# Altium schematic sheet Cheap_FOCer.SchDoc
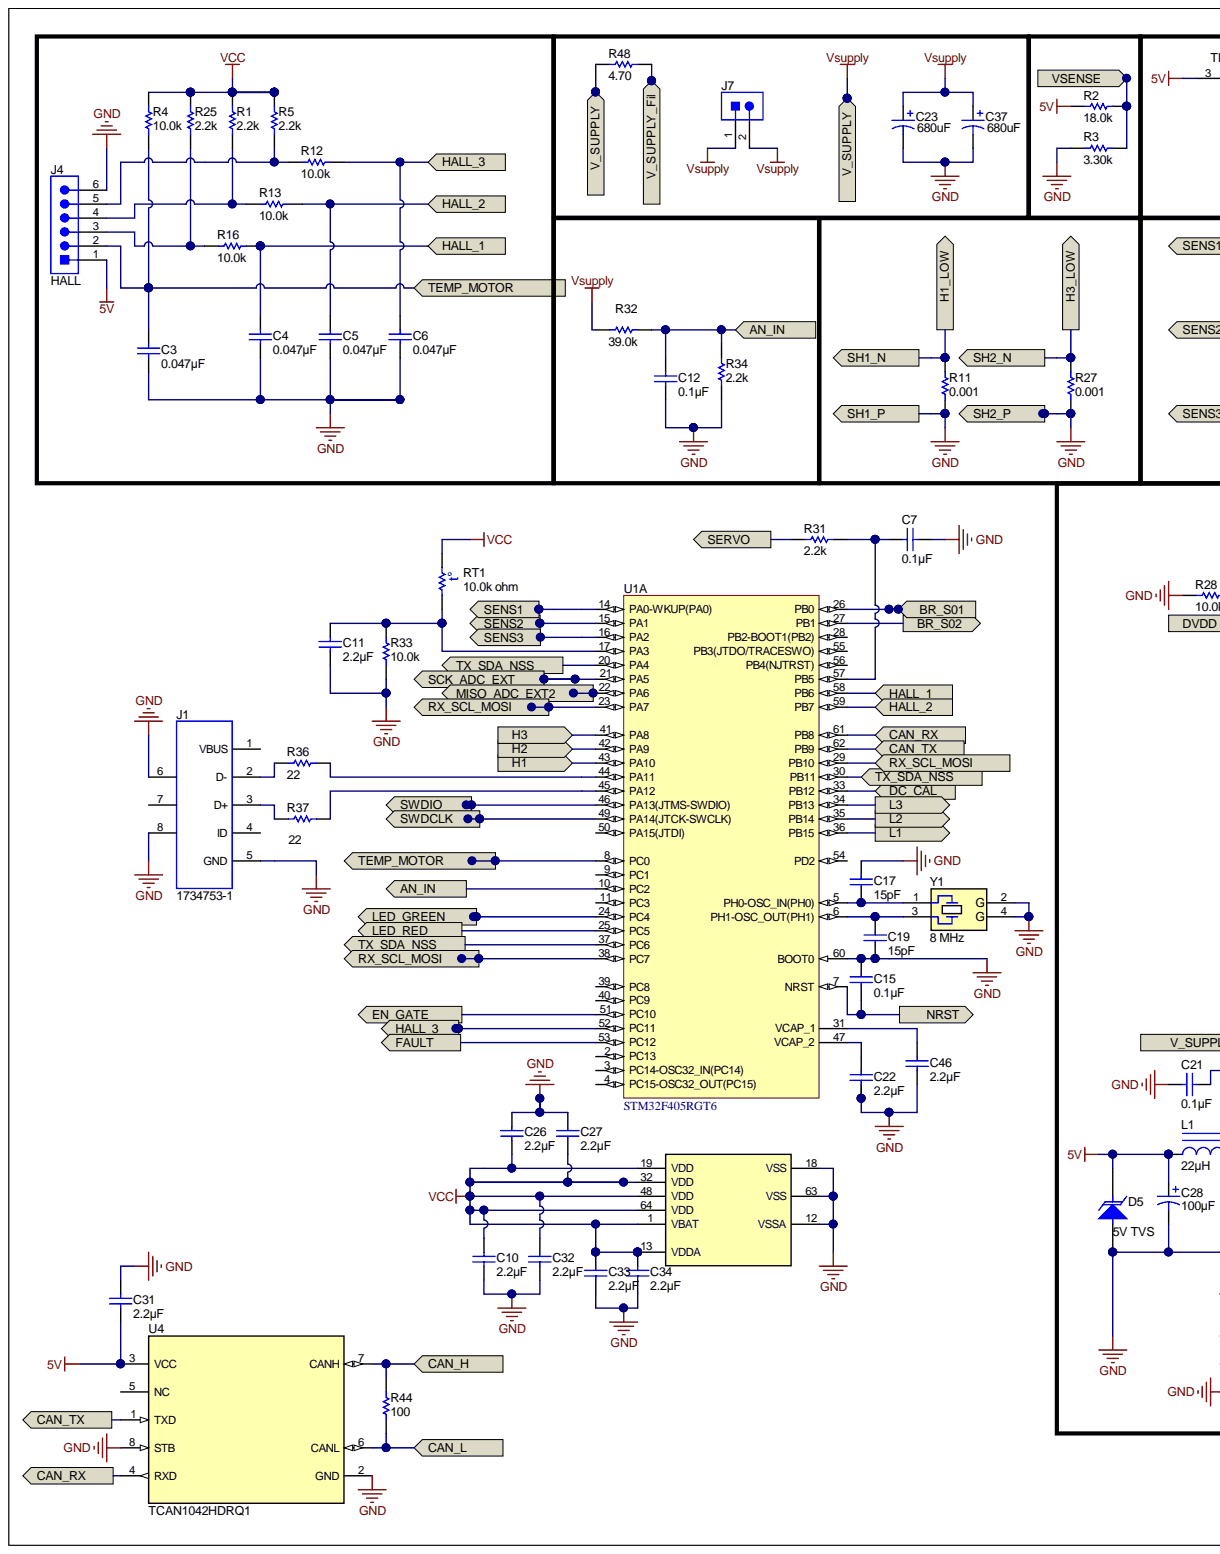
[diagram p0: Cheap_FOCer.SchDoc, left]
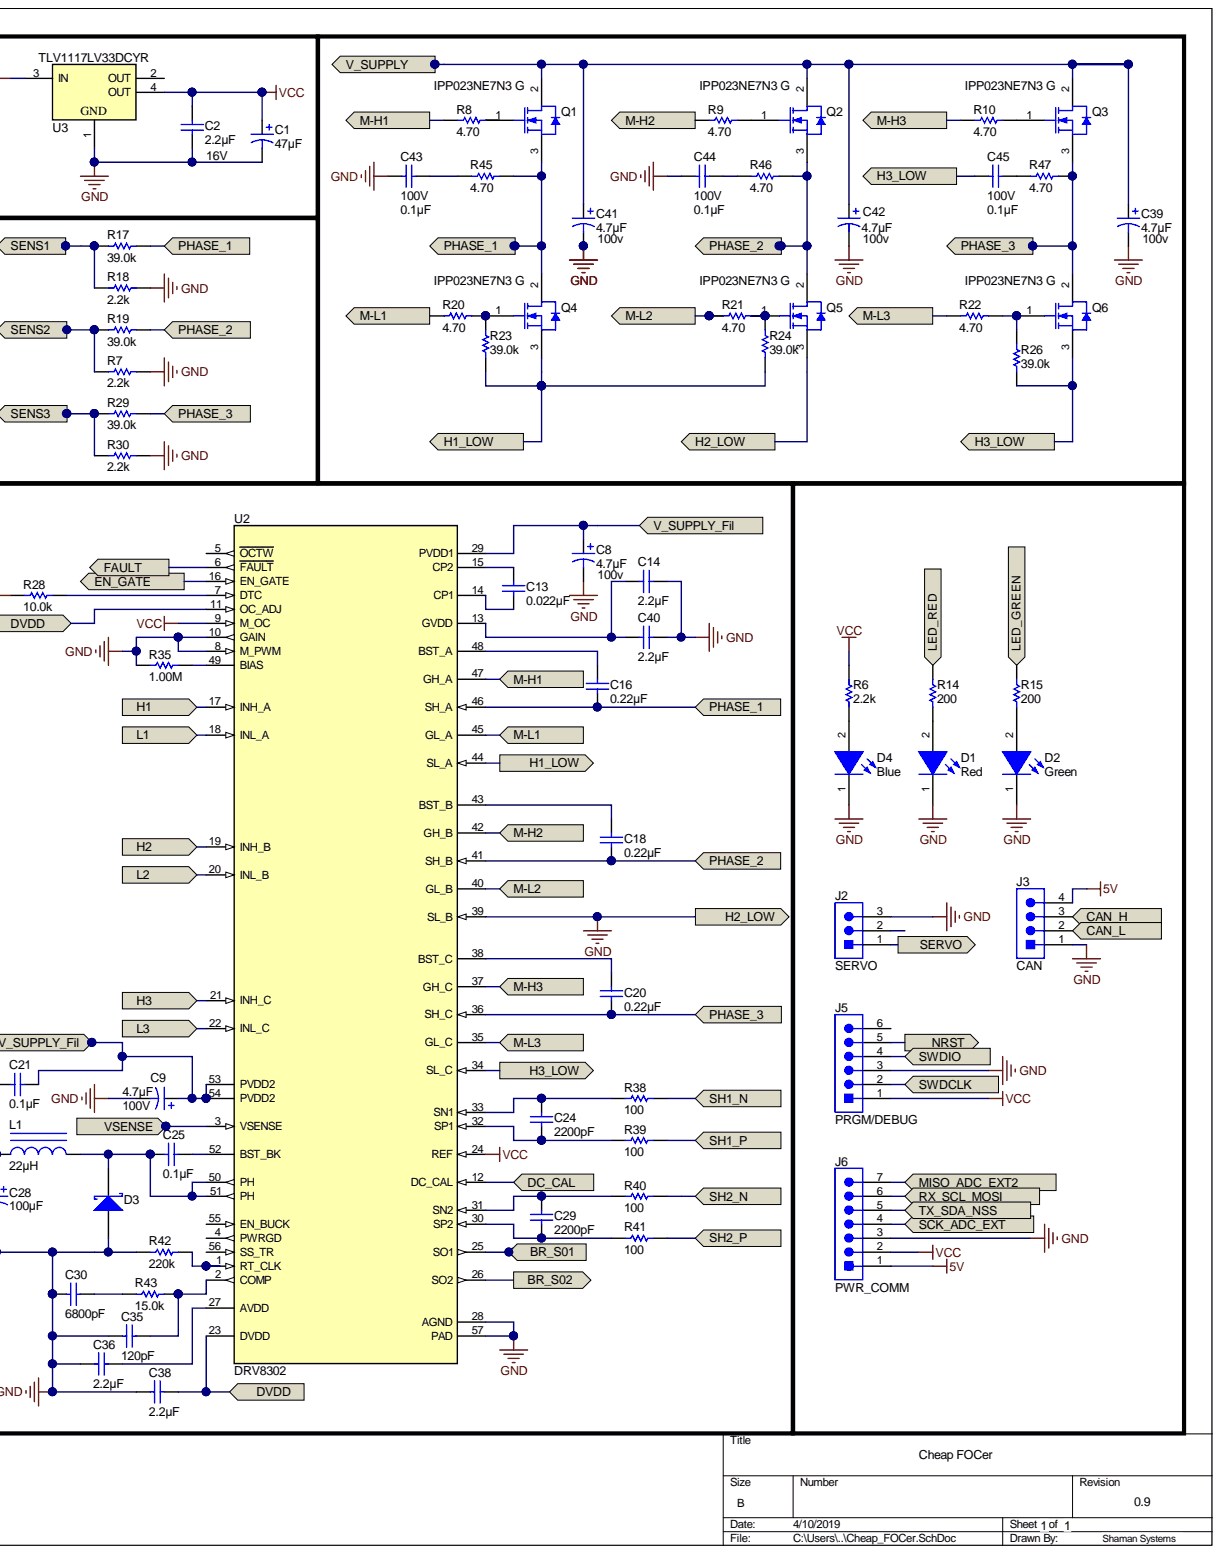
[diagram p1: Cheap_FOCer.SchDoc, right]

## Parts
C1  ValueDisplayed: 47µF  [FC_500x1100]  (hidden comment: EEU-FC1C470)
C2  Value2: 16V  ValueDisplayed: 2.2µF  [0805_HV]  (hidden comment: EMK212B7225KG-T)
C3  ValueDisplayed: 0.047µF  [0805_HV]  (hidden comment: GRM219R71E473KA01D)
C4  ValueDisplayed: 0.047µF  [0805_HV]  (hidden comment: GRM219R71E473KA01D)
C5  ValueDisplayed: 0.047µF  [0805_HV]  (hidden comment: GRM219R71E473KA01D)
C6  ValueDisplayed: 0.047µF  [0805_HV]  (hidden comment: GRM219R71E473KA01D)
C7  ValueDisplayed: 0.1µF  [0805_HV]  (hidden comment: C0805C104K4RACTU)
C8  Value2: 100v  ValueDisplayed: 4.7µF  [Chemi-Con_500x1100]  (hidden comment: EKMG500ELL4R7ME11D)
C9  Value2: 100V  ValueDisplayed: 4.7µF  [Chemi-Con_500x1100]  (hidden comment: EKMG500ELL4R7ME11D)
C10  ValueDisplayed: 2.2µF  [0805_HV]  (hidden comment: EMK212B7225KG-T)
C11  ValueDisplayed: 2.2µF  [0805_HV]  (hidden comment: EMK212B7225KG-T)
C12  ValueDisplayed: 0.1µF  [0805_HV]  (hidden comment: C0805C104K4RACTU)
C13  ValueDisplayed: 0.022µF  [0805_HV]  (hidden comment: 08055C223KAT2A)
C14  ValueDisplayed: 2.2µF  [0805_HV]  (hidden comment: EMK212B7225KG-T)
C15  ValueDisplayed: 0.1µF  [0805_HV]  (hidden comment: C0805C104K4RACTU)
C16  ValueDisplayed: 0.22µF  [0805_HV]  (hidden comment: GRM21BR71H224KA01L)
C17  value 15pF  [0805_HV]  (hidden comment: C0805C150J5GACTU)
C18  ValueDisplayed: 0.22µF  [0805_HV]  (hidden comment: GRM21BR71H224KA01L)
C19  value 15pF  [0805_HV]  (hidden comment: C0805C150J5GACTU)
C20  ValueDisplayed: 0.22µF  [0805_HV]  (hidden comment: GRM21BR71H224KA01L)
C21  ValueDisplayed: 0.1µF  [0805_HV]  (hidden comment: C0805C104K4RACTU)
C22  ValueDisplayed: 2.2µF  [0805_HV]  (hidden comment: EMK212B7225KG-T)
C23  ValueDisplayed: 680uF  [B41866_1600x2000]  (hidden comment: B41866C6567M)
C24  value 2200pF  [0805_HV]  (hidden comment: 08055A222JAT2A)
C25  ValueDisplayed: 0.1µF  [0805_HV]  (hidden comment: C0805C104K4RACTU)
C26  ValueDisplayed: 2.2µF  [0805_HV]  (hidden comment: EMK212B7225KG-T)
C27  ValueDisplayed: 2.2µF  [0805_HV]  (hidden comment: EMK212B7225KG-T)
C28  ValueDisplayed: 100µF  [FC_500x1100]  (hidden comment: EEU-FC1C470)
C29  value 2200pF  [0805_HV]  (hidden comment: 08055A222JAT2A)
C30  value 6800pF  [0805_HV]  (hidden comment: GRM2195C1H682FA01D)
C31  ValueDisplayed: 2.2µF  [0805_HV]  (hidden comment: EMK212B7225KG-T)
C32  ValueDisplayed: 2.2µF  [0805_HV]  (hidden comment: EMK212B7225KG-T)
C33  ValueDisplayed: 2.2µF  [0805_HV]  (hidden comment: EMK212B7225KG-T)
C34  ValueDisplayed: 2.2µF  [0805_HV]  (hidden comment: EMK212B7225KG-T)
C35  value 120pF  [0805_HV]  (hidden comment: 08055A121JAT2A)
C36  ValueDisplayed: 2.2µF  [0805_HV]  (hidden comment: EMK212B7225KG-T)
C37  ValueDisplayed: 680uF  [B41866_1600x2000]  (hidden comment: B41866C6567M)
C38  ValueDisplayed: 2.2µF  [0805_HV]  (hidden comment: EMK212B7225KG-T)
C39  Value2: 100v  ValueDisplayed: 4.7µF  [Chemi-Con_500x1100]  (hidden comment: EKMG500ELL4R7ME11D)
C40  ValueDisplayed: 2.2µF  [0805_HV]  (hidden comment: EMK212B7225KG-T)
C41  Value2: 100v  ValueDisplayed: 4.7µF  [Chemi-Con_500x1100]  (hidden comment: EKMG500ELL4R7ME11D)
C42  Value2: 100v  ValueDisplayed: 4.7µF  [Chemi-Con_500x1100]  (hidden comment: EKMG500ELL4R7ME11D)
C43  Value2: 100V  ValueDisplayed: 0.1µF  [FKP2_720x450x600]  (hidden comment: FKP2D001001D00HSSD)
C44  Value2: 100V  ValueDisplayed: 0.1µF  [FKP2_720x450x600]  (hidden comment: FKP2D001001D00HSSD)
C45  Value2: 100V  ValueDisplayed: 0.1µF  [FKP2_720x450x600]  (hidden comment: FKP2D001001D00HSSD)
C46  ValueDisplayed: 2.2µF  [0805_HV]  (hidden comment: EMK212B7225KG-T)
D1  value Red  Color: Red  [WL-SMCW_RED_0805]  (hidden comment: 150080SS75000)
D2  value Green  Color: Green  [WL-SMCW_Green_0805]  (hidden comment: 150080GS75000)
D3  (no value)  [SMB]
D4  value Blue  [WL-SMCW_RED_0805]  (hidden comment: 150080SS75000)
D5  5V TVS  value 5V  CompType: TVS  [SMA]
J1  1734753-1  [TE_1734753-1]
J2  SERVO  [Mill-Max_800-10-003-10-001000]
J3  CAN  [Mill-Max_800-10-004-10-001000]
J4  HALL  [Mill-Max_800-10-006-10-001000]
J5  PRGM/DEBUG  [Mill-Max_800-10-006-10-001000]
J6  PWR_COMM  [Mill-Max_800-10-007-10-001000]
J7  0022284023  [Molex_0022284023]  (value not drawn)
L1  ValueDisplayed: 22µH  [SRP6540]  (hidden comment: SRP6540-220M)
Q1  IPP023NE7N3 G  [TO-220AB]
Q2  IPP023NE7N3 G  [TO-220AB]
Q3  IPP023NE7N3 G  [TO-220AB]
Q4  IPP023NE7N3 G  [TO-220AB]
Q5  IPP023NE7N3 G  [TO-220AB]
Q6  IPP023NE7N3 G  [TO-220AB]
R1  value 2.2k  [0805_HV]  (hidden comment: CRCW08052K20JNEA)
R2  value 18.0k  [0805_HV]  (hidden comment: RC0805FR-0718KL)
R3  value 3.30k  [0805_HV]  (hidden comment: RG2012P-332-B-T5)
R4  value 10.0k  [0805_HV]  (hidden comment: CRCW080510K0FKEA)
R5  value 2.2k  [0805_HV]  (hidden comment: CRCW08052K20JNEA)
R6  value 2.2k  [0805_HV]  (hidden comment: CRCW08052K20JNEA)
R7  value 2.2k  [0805_HV]  (hidden comment: CRCW08052K20JNEA)
R8  value 4.70  [1206]  (hidden comment: RC1206FR-074R7L)
R9  value 4.70  [1206]  (hidden comment: RC1206FR-074R7L)
R10  value 4.70  [1206]  (hidden comment: RC1206FR-074R7L)
R11  value 0.001  Res_ohm: 0.001  [2512]  (hidden comment: ERJ-M1WTF1M0U)
R12  value 10.0k  [0805_HV]  (hidden comment: CRCW080510K0FKEA)
R13  value 10.0k  [0805_HV]  (hidden comment: CRCW080510K0FKEA)
R14  value 200  [0805_HV]  (hidden comment: CRCW0805200RFKEA)
R15  value 200  [0805_HV]  (hidden comment: CRCW0805200RFKEA)
R16  value 10.0k  [0805_HV]  (hidden comment: CRCW080510K0FKEA)
R17  value 39.0k  [0805_HV]  (hidden comment: RG2012P-393-B-T5)
R18  value 2.2k  [0805_HV]  (hidden comment: CRCW08052K20JNEA)
R19  value 39.0k  [0805_HV]  (hidden comment: RG2012P-393-B-T5)
R20  value 4.70  [1206]  (hidden comment: RC1206FR-074R7L)
R21  value 4.70  [1206]  (hidden comment: RC1206FR-074R7L)
R22  value 4.70  [1206]  (hidden comment: RC1206FR-074R7L)
R23  value 39.0k  [0805_HV]  (hidden comment: RG2012P-393-B-T5)
R24  value 39.0k  [0805_HV]  (hidden comment: RG2012P-393-B-T5)
R25  value 2.2k  [0805_HV]  (hidden comment: CRCW08052K20JNEA)
R26  value 39.0k  [0805_HV]  (hidden comment: RG2012P-393-B-T5)
R27  value 0.001  Res_ohm: 0.001  [2512]  (hidden comment: ERJ-M1WTF1M0U)
R28  value 10.0k  [0805_HV]  (hidden comment: CRCW080510K0FKEA)
R29  value 39.0k  [0805_HV]  (hidden comment: RG2012P-393-B-T5)
R30  value 2.2k  [0805_HV]  (hidden comment: CRCW08052K20JNEA)
R31  value 2.2k  [0805_HV]  (hidden comment: CRCW08052K20JNEA)
R32  value 39.0k  [0805_HV]  (hidden comment: RG2012P-393-B-T5)
R33  value 10.0k  [0805_HV]  (hidden comment: CRCW080510K0FKEA)
R34  value 2.2k  [0805_HV]  (hidden comment: CRCW08052K20JNEA)
R35  ValueDisplayed: 1.00M  [0805_HV]  (hidden comment: ERJ-6ENF1004V)
R36  value 22  [0805_HV]  (hidden comment: CRCW080522R0JNEA)
R37  value 22  [0805_HV]  (hidden comment: CRCW080522R0JNEA)
R38  value 100  [0805_HV]  (hidden comment: CRCW0805100RFKEA)
R39  value 100  [0805_HV]  (hidden comment: CRCW0805100RFKEA)
R40  value 100  [0805_HV]  (hidden comment: CRCW0805100RFKEA)
R41  value 100  [0805_HV]  (hidden comment: CRCW0805100RFKEA)
R42  value 220k  [0805_HV]  (hidden comment: RG2012P-224-B-T5)
R43  value 15.0k  [0805_HV]  (hidden comment: ERJ-6ENF1502V)
R44  value 100  [0805_HV]  (hidden comment: CRCW0805100RFKEA)
R45  value 4.70  [1206]  (hidden comment: RC1206FR-074R7L)
R46  value 4.70  [1206]  (hidden comment: RC1206FR-074R7L)
R47  value 4.70  [1206]  (hidden comment: RC1206FR-074R7L)
R48  value 4.70  [1206]  (hidden comment: RC1206FR-074R7L)
RT1  value 10.0k ohm  [Thermistor_103AT-2]  (hidden comment: 103AT-2)
U1  STM32F405RGT6  [STM-LQFP64_N]  (part A)
U2  PartNumber: DRV8302  [DCA0056A_L]  (hidden comment: DRV8301DCA)
U3  TLV1117LV33DCYR  [DCY0004A_N]
U4  TCAN1042HDRQ1  [D0008A_L]
Y1  Frequency_Fixed: 8 MHz  [ABRACON_ABM3B]  (hidden comment: ABM3B-8.000MHZ-10-1-U-T)

## Ports
AN_IN, BR_S01, BR_S02, CAN_H, CAN_L, CAN_RX, CAN_TX, DC_CAL, DVDD, EN_GATE, FAULT, H1, H1_LOW, H2, H2_LOW, H3, H3_LOW, HALL_1, HALL_2, HALL_3, L1, L2, L3, LED_GREEN, LED_RED, M-H1, M-H2, M-H3, M-L1, M-L2, M-L3, MISO_ADC_EXT2, NRST, PHASE_1, PHASE_2, PHASE_3, RX_SCL_MOSI, SCK_ADC_EXT, SENS1, SENS2, SENS3, SERVO, SH1_N, SH1_P, SH2_N, SH2_P, SWDCLK, SWDIO, TEMP_MOTOR, TX_SDA_NSS, V_SUPPLY, V_SUPPLY_Fil, VSENSE

## Nets
5V: C28.1 C31.1 D5.1 J3.4 J4.1 J6.1 L1.1 R2.2 U3.3(IN) U4.3(VCC)
VCC: C1.1 C2.1 C10.1 C26.2 C27.1 C32.1 C33.1 C34.1 J5.1 J6.2 R1.1 R4.2 R5.1 R6.1 R25.1 RT1.2 U1.1(VBAT) U1.13(VDDA) U1.19(VDD) U1.32(VDD) U1.48(VDD) U1.64(VDD) U2.9(M_OC) U2.24(REF) U3.4(OUT)
AN_IN: C12.1 R32.2 R34.2 U1.10(PC2) ; port AN_IN
BR_S01: U1.26(PB0) U2.25(SO1) ; port BR_S01
BR_S02: U1.27(PB1) U2.26(SO2) ; port BR_S02
CAN_H: J3.3 R44.2 U4.7(CANH) ; port CAN_H
CAN_L: J3.2 R44.1 U4.6(CANL) ; port CAN_L
CAN_RX: U1.61(PB8) U4.4(RXD) ; port CAN_RX
CAN_TX: U1.62(PB9) U4.1(TXD) ; port CAN_TX
DC_CAL: U1.33(PB12) U2.12(DC_CAL) ; port DC_CAL
DVDD: C38.1 U2.11(OC_ADJ) U2.23(DVDD) ; port DVDD
EN_GATE: U1.51(PC10) U2.16(EN_GATE) ; port EN_GATE
FAULT: U1.53(PC12) U2.6(FAULT) ; port FAULT
GND: C1.2 C2.2 C3.2 C4.2 C5.2 C6.2 C7.2 C8.2 C9.2 C10.2 C11.2 C12.2 C14.1 C15.2 C17.1 C19.2 C21.1 C22.1 C23.2 C26.1 C27.2 C28.2 C30.2 C31.2 C32.2 C33.2 C34.2 C35.2 C36.1 C37.2 C38.2 C39.2 C40.1 C41.2 C42.2 C43.2 C44.2 C46.2 D1.1 D2.1 D3.2 D4.1 D5.2 J1.5(GND) J1.6 J1.8 J2.3 J3.1 J4.6 J5.3 J6.3 Q5.3 R3.2 R7.1 R11.1 R18.1 R27.1 R28.2 R30.1 R33.2 R34.1 R35.1 R39.2 R41.2 R42.1 U1.12(VSSA) U1.18(VSS) U1.60(BOOT0) U1.63(VSS) U2.8(M_PWM) U2.10(GAIN) U2.28(AGND) U2.39(SL_B) U2.57(PAD) U3.1 U4.2(GND) U4.8(STB) Y1.2(G) Y1.4(G) ; port H2_LOW, port SH1_P, port SH2_P
H1: U1.43(PA10) U2.17(INH_A) ; port H1
H1_LOW: Q4.3 R11.2 R23.1 R24.1 R38.2 U2.44(SL_A) ; port H1_LOW, port SH1_N
H2: U1.42(PA9) U2.19(INH_B) ; port H2
H3: U1.41(PA8) U2.21(INH_C) ; port H3
H3_LOW: C45.1 Q6.3 R26.1 R27.2 R40.2 U2.34(SL_C) ; port H3_LOW, port SH2_N
HALL_1: C4.1 R16.1 U1.58(PB6) ; port HALL_1
HALL_2: C5.1 R13.1 U1.59(PB7) ; port HALL_2
HALL_3: C6.1 R12.1 U1.52(PC11) ; port HALL_3
L1: U1.36(PB15) U2.18(INL_A) ; port L1
L2: U1.35(PB14) U2.20(INL_B) ; port L2
L3: U1.34(PB13) U2.22(INL_C) ; port L3
LED_GREEN: R15.2 U1.24(PC4) ; port LED_GREEN
LED_RED: R14.2 U1.25(PC5) ; port LED_RED
M-H1: R8.1 U2.47(GH_A) ; port M-H1
M-H2: R9.1 U2.42(GH_B) ; port M-H2
M-H3: R10.1 U2.37(GH_C) ; port M-H3
M-L1: R20.1 U2.45(GL_A) ; port M-L1
M-L2: R21.1 U2.40(GL_B) ; port M-L2
M-L3: R22.1 U2.35(GL_C) ; port M-L3
MISO_ADC_EXT2: J6.7 U1.22(PA6) ; port MISO_ADC_EXT2
NRST: C15.1 J5.5 U1.7(NRST) ; port NRST
PHASE_1: C16.2 Q1.3 Q4.2 R17.1 R45.2 U2.46(SH_A) ; port PHASE_1
PHASE_2: C18.2 Q2.3 Q5.2 R19.1 R46.2 U2.41(SH_B) ; port PHASE_2
PHASE_3: C20.2 Q3.3 Q6.2 R29.1 R47.2 U2.36(SH_C) ; port PHASE_3
RX_SCL_MOSI: J6.6 U1.23(PA7) U1.29(PB10) U1.38(PC7) ; port RX_SCL_MOSI
SCK_ADC_EXT: J6.4 U1.21(PA5) ; port SCK_ADC_EXT
SENS1: R17.2 R18.2 U1.14(PA0-WKUP(PA0)) ; port SENS1
SENS2: R7.2 R19.2 U1.15(PA1) ; port SENS2
SENS3: R29.2 R30.2 U1.16(PA2) ; port SENS3
SERVO: J2.1 R31.1 ; port SERVO
SWDCLK: J5.2 U1.49(PA14(JTCK-SWCLK)) ; port SWDCLK
SWDIO: J5.4 U1.46(PA13(JTMS-SWDIO)) ; port SWDIO
TEMP_MOTOR: C3.1 J4.2 R4.1 U1.8(PC0) ; port TEMP_MOTOR
TX_SDA_NSS: J6.5 U1.20(PA4) U1.30(PB11) U1.37(PC6) ; port TX_SDA_NSS
V_SUPPLY_Fil: C8.1 C9.1 C21.2 R48.2 U2.29(PVDD1) U2.53(PVDD2) U2.54(PVDD2) ; port V_SUPPLY_Fil
VSENSE: R2.1 R3.1 U2.3(VSENSE) ; port VSENSE
Vsupply: C23.1 C37.1 C39.1 C41.1 C42.1 J7.1 J7.2 Q1.2 Q2.2 Q3.2 R32.1 R48.1 ; port V_SUPPLY
NetC7_1: C7.1 R31.2 U1.57(PB5)
NetC11_1: C11.1 R33.1 RT1.1 U1.17(PA3)
NetC13_1: C13.1 U2.15(CP2)
NetC13_2: C13.2 U2.14(CP1)
NetC14_2: C14.2 C40.2 U2.13(GVDD)
NetC16_1: C16.1 U2.48(BST_A)
NetC17_2: C17.2 U1.5(PH0-OSC_IN(PH0)) Y1.1
NetC18_1: C18.1 U2.43(BST_B)
NetC19_1: C19.1 U1.6(PH1-OSC_OUT(PH1)) Y1.3
NetC20_1: C20.1 U2.38(BST_C)
NetC22_2: C22.2 U1.47(VCAP_2)
NetC24_1: C24.1 R39.1 U2.32(SP1)
NetC24_2: C24.2 R38.1 U2.33(SN1)
NetC25_1: C25.1 D3.1 L1.2 U2.50(PH) U2.51(PH)
NetC25_2: C25.2 U2.52(BST_BK)
NetC29_1: C29.1 R41.1 U2.30(SP2)
NetC29_2: C29.2 R40.1 U2.31(SN2)
NetC30_1: C30.1 R43.1
NetC35_1: C35.1 R43.2 U2.2(COMP)
NetC36_2: C36.2 U2.27(AVDD)
NetC43_1: C43.1 R45.1
NetC44_1: C44.1 R46.1
NetC45_2: C45.2 R47.1
NetC46_1: C46.1 U1.31(VCAP_1)
NetD1_2: D1.2 R14.1
NetD2_2: D2.2 R15.1
NetD4_2: D4.2 R6.2
NetJ1_2: J1.2(D-) R36.1
NetJ1_3: J1.3(D+) R37.1
NetJ4_3: J4.3 R16.2 R25.2
NetJ4_4: J4.4 R1.2 R13.2
NetJ4_5: J4.5 R5.2 R12.2
NetQ1_1: Q1.1 R8.2
NetQ2_1: Q2.1 R9.2
NetQ3_1: Q3.1 R10.2
NetQ4_1: Q4.1 R20.2 R23.2
NetQ5_1: Q5.1 R21.2 R24.2
NetQ6_1: Q6.1 R22.2 R26.2
NetR28_1: R28.1 U2.7(DTC)
NetR35_2: R35.2 U2.49(BIAS)
NetR36_2: R36.2 U1.44(PA11)
NetR37_2: R37.2 U1.45(PA12)
NetR42_2: R42.2 U2.1(RT_CLK)

Not connected: J1 1(VBUS) 4(ID) 7; J2 2; J5 6; U1 2(PC13) 3(PC14-OSC32_IN(PC14)) 4(PC15-OSC32_OUT(PC15)) 9(PC1) 11(PC3) 28(PB2-BOOT1(PB2)) 39(PC8) 40(PC9) 50(PA15(JTDI)) 54(PD2) 55(PB3(JTDO/TRACESWO)) 56(PB4(NJTRST)); U2 4(PWRGD) 5(OCTW) 55(EN_BUCK) 56(SS_TR); U3 2(OUT); U4 5(NC)
